# Altium schematic sheet PowerInput.SchDoc(PowerInput)
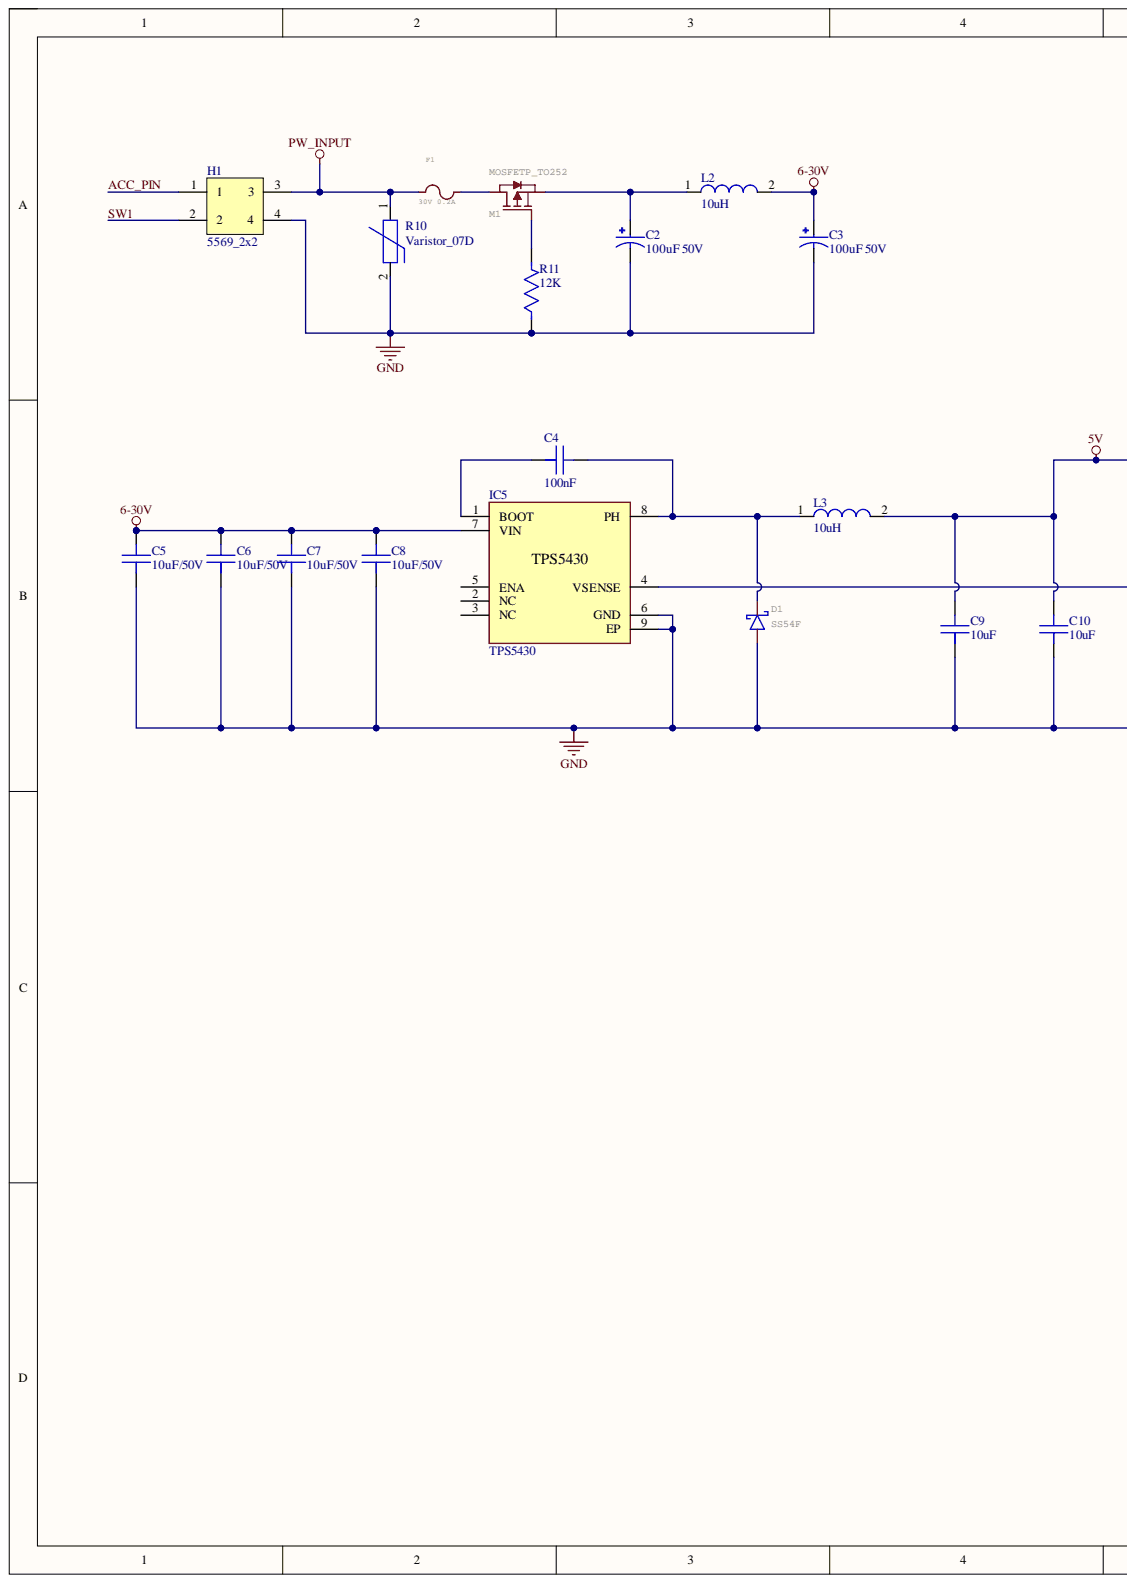
[diagram p0: PowerInput.SchDoc, left]
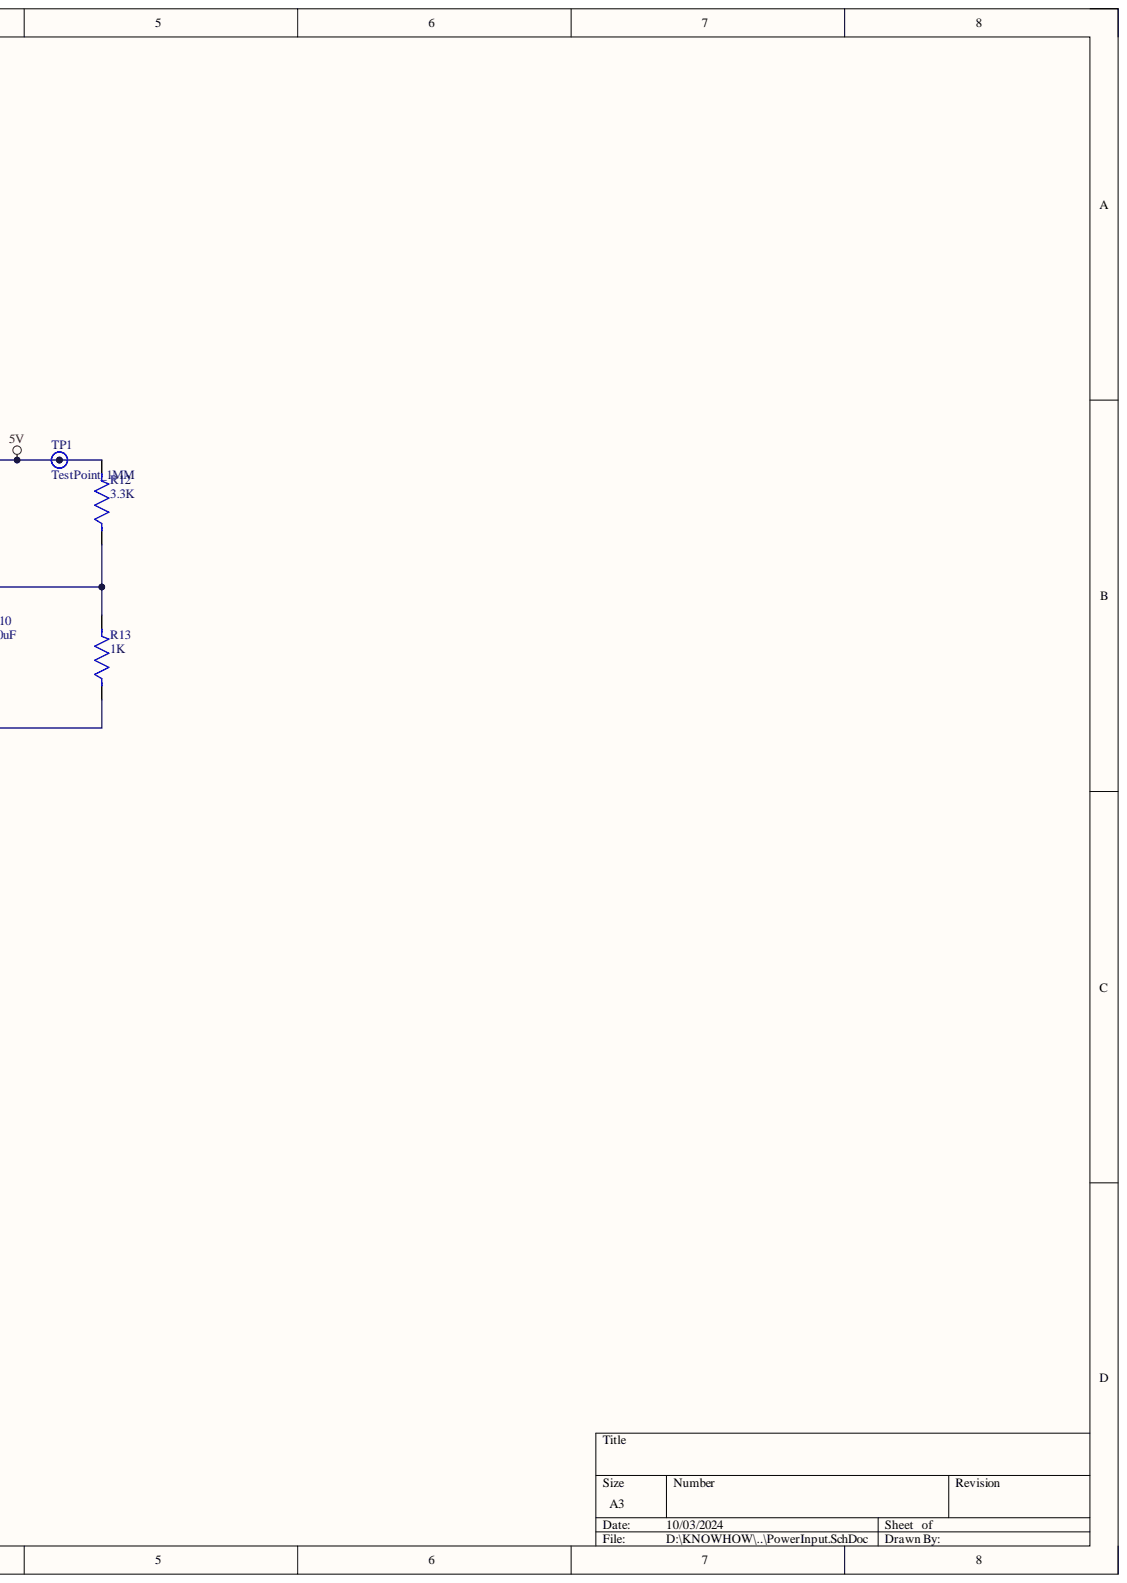
[diagram p1: PowerInput.SchDoc, right]

## Parts
C2  100uF 50V  [CAP_AL_8x12.5]
C3  100uF 50V  [CAP_AL_8x12.5]
C4  100nF  [C0603]
C5  10uF/50V  [C0805]
C6  10uF/50V  [C0805]
C7  10uF/50V  [C0805]
C8  10uF/50V  [C0805]
C9  10uF  [C0805]
C10  10uF  [C0805]
D1  SS54F  [SMAF]
F1  30V 0.2A  [FUSE_MF-MSMF150]
H1  5569_2x2  [5569_2x2]
IC5  TPS5430  [SOIC-8E]
L2  10uH  [L0630]
L3  10uH  [L0630]
M1  MOSFETP_TO252  [TO252-3]
R10  Varistor_07D  [Varistor_07D]
R11  12K  [R0603]
R12  3.3K  [R0603]
R13  1K  [R0603]
TP1  TestPoint_1MM  [TestPoint_1MM]

## Nets
5V: C9.2 C10.2 L3.2 R12.2 TP1.1
6-30V: C3.1 C5.2 C6.2 C7.2 C8.2 IC5.7(VIN) L2.2
GND: C2.2 C3.2 C5.1 C6.1 C7.1 C8.1 C9.1 C10.1 D1.A H1.4 IC5.6(GND) IC5.9(EP) R10.2 R11.1 R13.1
PW_INPUT: F1.1 H1.3 R10.1
ACC_PIN: H1.1
SW1: H1.2
NetC2_1: C2.1 L2.1 M1.2
NetC4_1: C4.1 IC5.1(BOOT)
NetC4_2: C4.2 D1.C IC5.8(PH) L3.1
NetF1_2: F1.2 M1.3
NetIC5_4: IC5.4(VSENSE) R12.1 R13.2
NetM1_1: M1.1 R11.2

Not connected: IC5 2(NC) 3(NC) 5(ENA)

## Library data of the parts
C2 C3 = CAP_AL_8x12.5: Aluminum Electrolytic Capacitors - Radial Leaded 50VDC 1200uF 10000H 16x25mm; MF: Panasonic; MP: EEU-FS1H122; Availability: In Stock; Check_prices: https://www.snapeda.com/parts/EEU-FS1H122/Panasonic/view-part/?ref=eda; Component Kind: Standard; Package: RADIAL-2 Panasonic; Price: None; Purchase-URL: https://pricing.snapeda.com/search/part/EEU-FS1H122/?ref=eda; SnapEDA_Link: https://www.snapeda.com/parts/EEU-FS1H122/Panasonic/view-part/?ref=snap; Library Name: UY_Schlib.SchLib
C4 = Capacitor 0603: Component Kind: Standard; Library Name: UY_Schlib.SchLib
C5-C10 = Capacitor 0805: Component Kind: Standard; Library Name: UY_Schlib.SchLib
D1 = SS54F: GateName_1: G$1; SymbolName_1: DIODE_S; Component Kind: Standard; DeviceSetName: SS54F; LibraryName: Uy_SMD; Package: SMAF; Library Name: UY_Schlib.SchLib
F1 = FUSE_MF-MSMF150: GateName_1: G$1; MF: Bourns; AVAILABILITY: Unavailable; Component Kind: Standard; DeviceSetName: MF-MSMF150; LibraryName: Uy_SMD; MP: MF-MSMF150; Package: FUSER_MF-MSMF150; PRICE: None; SymbolName_1: MF-MSMF150; Library Name: UY_Schlib.SchLib
IC5 = TPS5430: Buck Step-Down Converter  (5.5V to 36V Input, 3A); MF: Texas Instruments; MP: TPS5430DDA; Availability: Unavailable; Component Kind: Standard; DigiKey-Purchase-URL: https://snapeda.com/shop?store=DigiKey&id=226174; Mouser-Purchase-URL: https://snapeda.com/shop?store=Mouser&id=226174; Package: HSOIC-8 Texas Instruments; Price: None; SnapEDA_Link: https://www.snapeda.com/parts/TPS5430DDA/Texas%20Instruments/view-part/226174/?ref=snap; Texas_Instruments-Purchase-URL: https://snapeda.com/shop?store=Texas+Instruments&id=226174; Library Name: UY_Schlib.SchLib
L2 L3 = Inductor 0630: HIGH POWER INDUCTOR 1040; MF: Wurth Electronics; MP: 74437368047; Availability: Unavailable; Component Kind: Standard; Package: Nonstandard Wurth Electronics; Price: None; SnapEDA_Link: https://www.snapeda.com/parts/74437368047/Wurth%20Electronics%20Inc./view-part/286863/?ref=snap; Library Name: UY_Schlib.SchLib
M1 = MOSFETP_TO252: SOT-23; DeviceSetName: MOSFETN; GateName_1: G$1; SymbolName_1: MOSFET_N; Component Kind: Standard; LibraryName: Uy_SMD; Package: SOT23(1); Library Name: UY_Schlib.SchLib
R11-R13 = Resistor 0603: SMD Resistor 0603; Manufacturer: Yageo; Manufacturer Part Number: RC0603FR-071KL; Max Power Dissipation: 100mW; Resistance Tolerance: 1%; Temperature Coefficient: 100ppm/°C; Voltage Rating: 50V; Case Code (Imperial): 0603; Case Code (Metric): 1608; Case/Package: 0603; Category: Chip SMD Resistors; Component Kind: Standard; Construction: Rectangular; Contact Plating: Tin,Matte; Depth: 0.8mm; Features: Moisture Resistant; Height: 0.45mm; Lead Free: 5697LeadFree; Length: 1.6mm; Max Operating Temperature: 155°C; Military Standard: Not; Min Operating Temperature: -55°C; Number of Terminations: 2; Packaging: Tape and Reel; Power Rating: 100mW; Pricing: 1=0.1, 10=0.018, 50=0.0106, 100=0.0088, 500=0.00592, 1000=0.00505 (USD); Reach SVHC Compliant: No; Resistor Type: Thick Film; RoHS: Yes; RoHS Compliant: Yes; Series: RC; Stock: 18662657; Supplier: Digi-Key; Supplier Part Number: 311-1.00KHRDKR-ND; Technology: THICK FILM; Termination: SMD/SMT; Tolerance: 1%; Library Name: UY_Schlib.SchLib
H1 = 5569_2x2: Component Kind: Standard; Library Name: UY_5569_Header.SchLib
R10 = Varistor_07D: Component Kind: Standard; Library Name: UY_Schlib.SchLib
TP1 = TestPoint_1MM: Component Kind: Standard; Library Name: UY_Schlib.SchLib
